# Altium schematic sheet VoltageRegulator1.SchDoc(VoltageRegulator1)
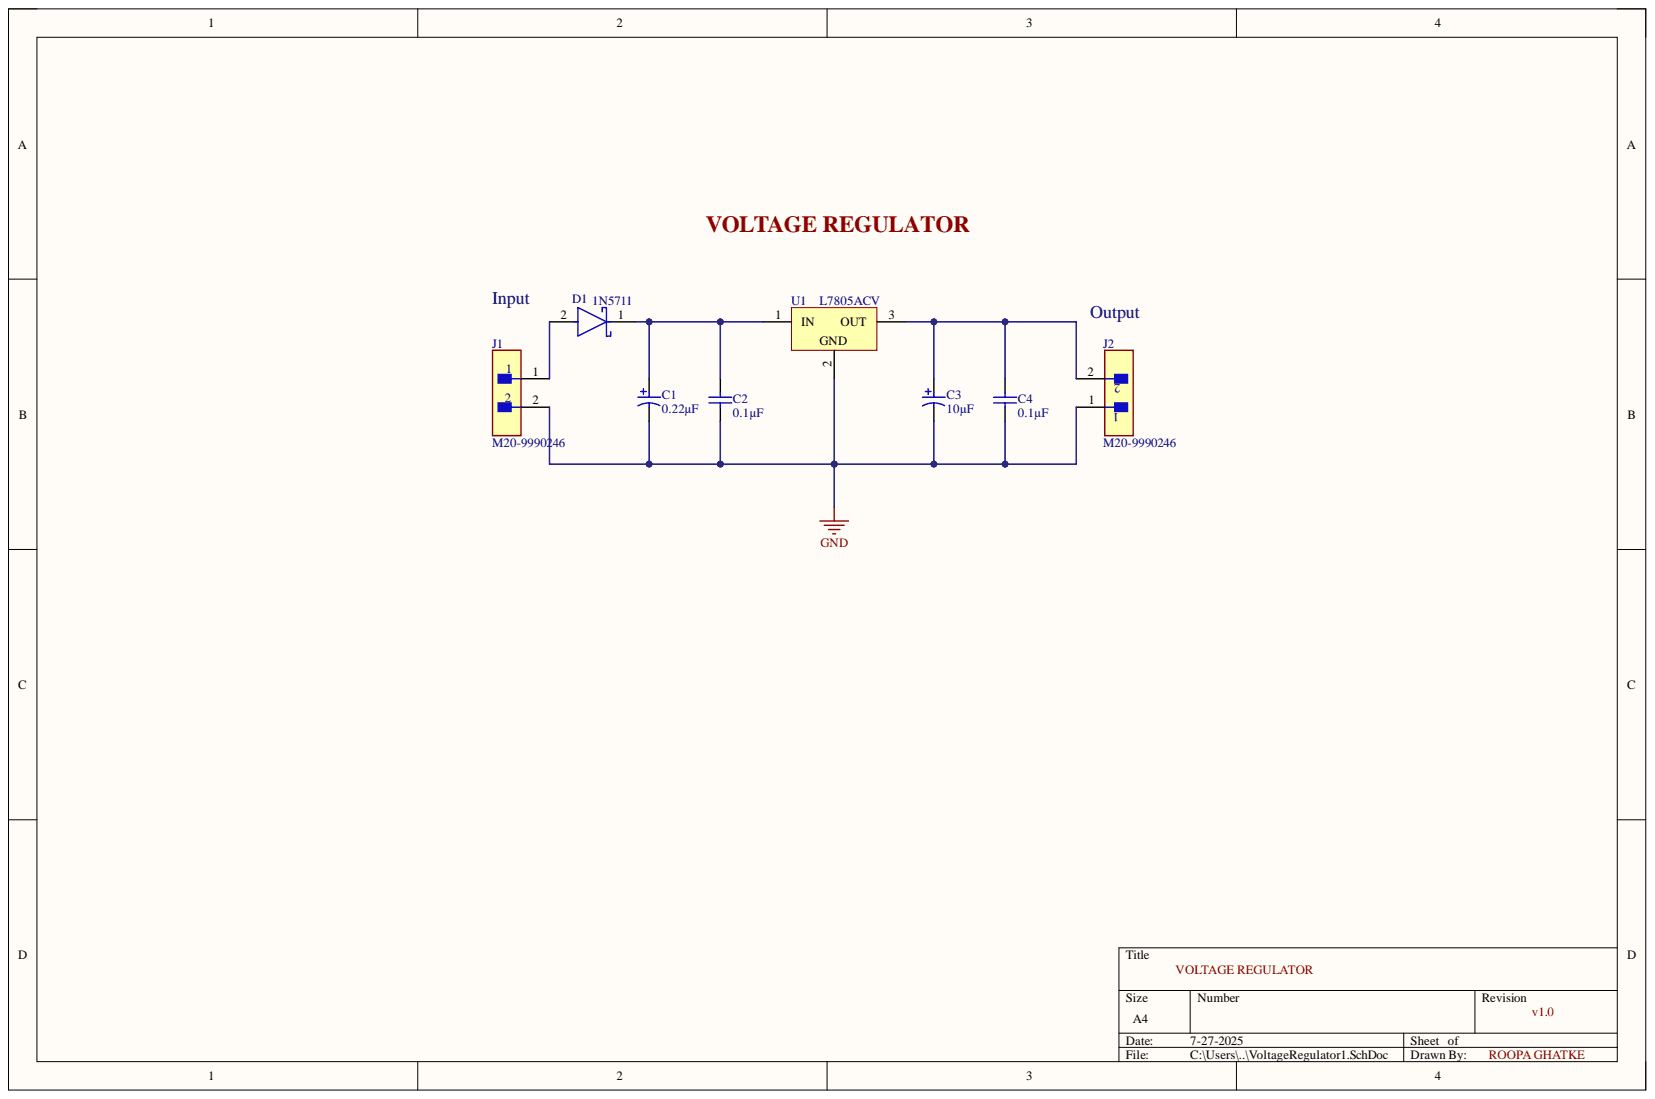
[diagram p0: VoltageRegulator1.SchDoc]

## Parts
C1  0.22µF  [CAPPR55-200-1100X500X1200]
C2  0.1µF  [CAPR51-254-508X318X736]
C3  10µF  [CAPPR55-200-1100X500X1200]
C4  0.1µF  [CAPR51-254-508X318X736]
D1  1N5711  [FP-DO-35-MFG]
J1  M20-9990246  [FP-M20-9990246-MFG]
J2  M20-9990246  [FP-M20-9990246-MFG]
U1  L7805ACV  [TO220]

## Nets
GND: C1.2 C2.2 C3.2 C4.1 J1.2 J2.1 U1.2
NetC1_1: C1.1 C2.1 D1.1 U1.1
NetC3_1: C3.1 C4.2 J2.2 U1.3
NetD1_2: D1.2 J1.1

## Library data of the parts
C1 = CMP-1665-00040-2: Aluminum Electrolytic Capacitor, 2.2 uF, +/- 20%, 50 V, -40 to 85 degC, 2-Pin THD, RoHS, Bulk; Manufacturer: Panasonic; Capacitance: 2.2uF; Dielectric Material: Aluminium; Dissipation Factor: 0.12%; Lead Diameter: 0.5mm; Lead/Base Style: Straight; Leakage Current: 3uA; Life (Hours): 2000Hour; Ripple Current: 20mA; Voltage: 50V; Case/Package: Radial; Component Kind: Standard; Contact Plating: Tin; Datasheet URL: https://industrial.panasonic.com/cdbs/www-data/pdf/RDF0000/ABA0000C1218.pdf; Datasheet Version: Rev. 05, 10/2016; Diameter: 5mm; Height: 11mm; Height - Seated (Max): 0.472inch; Lead Free: LeadFree; Lead Pitch: 2mm; Length: 11mm; Library Name: Altium Content Vault; Manufacturer URL: http://www.panasonic.com/; Max Operating Temperature: 85degC; Min Operating Temperature: -40degC; Mount: ThroughHole; Number of Pins: 2; Package Description: 2-Pin Radial Lead, Dia 5 mm, Height 12 mm; Package Quantity: 200; Package Reference: CAPPR55-200-1100X500X1200; Packaging: Bulk; Radiation Hardening: No; REACH SVHC: NoSVHC; RoHSCompliant: true; Termination: Radial; Tolerance: 20%; Voltage Rating: 50V; Voltage Rating (DC): 50V
C2 C4 = CMP-1664-00004-1: Radial Leaded Multilayer Ceramic Capacitor, 0.1 uF, +/- 10%, 50 V, -55 to 125 degC, 2-Pin THD, RoHS, Tape and Reel; Case\Package: SOT-23-5; Dropout Voltage: 260 mV; Max Input Voltage: 8 V; Max Power Dissipation: 250 mW; Output Current: 150 mA; Output Voltage: 5 V; Outputs: 1; Component Kind: Standard; ComponentLink1Description: Manufacturer URL; ComponentLink1URL: http://www.kemet.com/; ComponentLink2Description: Datasheet; ComponentLink2URL: http://www.kemet.com/docfinder?Partnumber=C320C104K5R5TA; DatasheetVersion: Dec-15; Height: 1.3 mm; Length: 3 mm; Library Name: Altium Content Vault; Max Operating Temperature: 85 degC; Min Operating Temperature: -40 degC; Mount: Surface Mount; Package Quantity: 3000; PackageDescription: 2-Pin Through Hole Device, Body 5.08 x 3.18 mm, Pitch 2.54 mm; PackageReference: CAPR51-254-508X318X736; Packaging: Tape and Reel; Pins: 5; Radiation Hardening: No; RoHSCompliant: true; Width: 1.8 mm
C3 = CMP-1665-00036-2: Aluminum Electrolytic Capacitor, 22 uF, +/- 20%, 50 V, -40 to 85 degC, 2-Pin THD, RoHS, Bulk; Manufacturer: Panasonic; Capacitance: 22uF; Dissipation Factor: 0.12%; Lead Diameter: 0.5mm; Lead/Base Style: Straight; Leakage Current: 3uA; Life (Hours): 2000Hour; Ripple Current: 100mA; Voltage: 50V; Case/Package: Radial; Component Kind: Standard; Datasheet URL: https://industrial.panasonic.com/cdbs/www-data/pdf/RDF0000/ABA0000C1218.pdf; Datasheet Version: Rev. 05, 10/2016; Diameter: 5mm; Height: 11mm; Height - Seated (Max): 0.472inch; Lead Pitch: 2mm; Length: 11mm; Library Name: Altium Content Vault; Manufacturer URL: http://www.panasonic.com/; Max Operating Temperature: 85degC; Min Operating Temperature: -40degC; Mount: ThroughHole; Package Description: 2-Pin Radial Lead, Dia 5 mm, Height 12 mm; Package Quantity: 200; Package Reference: CAPPR55-200-1100X500X1200; Packaging: Bulk; Radiation Hardening: No; REACH SVHC: NoSVHC; Ripple Current (AC): 100mA; RoHSCompliant: true; Termination: Radial; Tolerance: 20%; Voltage Rating: 50V; Voltage Rating (DC): 50V
D1 = CMP-2000-05519-2: RF DIODE SCHOTTKY 70V 430MW DO35; Manufacturer: STMicroelectronics; Capacitance: 2pF; Forward Current: 15mA; Max Junction Temp: +200°C; Value: 2pF; Automotive: No; Category: Diode; Component Kind: Standard; ComponentLink2Description: Datasheet; ComponentLink2URL: https://www.st.com/resource/en/datasheet/1n5711.pdf; Device Class L1: Discrete Semiconductors; Device Class L2: Diodes; Device Class L3: Schottky Diodes; Digikey Description: RF DIODE SCHOTTKY 70V 430MW DO35; Height: 2mm; Ipc Land Pattern Name: DIOPA762W75L450D200; Lead Free: Yes; Library Name: Altium Content Vault; Manufacturer Part Number: 1N5711; Max Repetitive Reverse Voltage: 70V; Mouser Description: Schottky Diodes & Rectifiers 15mA 70 Volt; Octopart: https://octopart.com/1n5711-stmicroelectronics-55399277; Package: DO-35; Reverse Voltage: 70V; RoHS: TRUE; Temperature: -65°C to +200°C; Temperature Range High: +200°C; Temperature Range Low: -65°C
J1 J2 = CMP-15831-000009-1: CONN HEADER VERT 2POS 2.54MM; Manufacturer: Harwin; Conn Gender: Male; Conn Orientation: Vertical; Current Rating: 3A; Number Of Contacts: 2; Automotive: No; Category: Conn; Component Kind: Standard; ComponentLink2Description: Datasheet; ComponentLink2URL: https://cdn.harwin.com/pdfs/M20-999.pdf; Contact Material: Tin,Nickel; Device Class L1: Connectors; Device Class L2: Headers and Wire Housings; Device Class L3: unset; Digikey Description: CONN HEADER VERT 2POS 2.54MM; Height: 8.99mm; Lead Free: Yes; Library Name: Altium Content Vault; Manufacturer Part Number: M20-9990246; Mouser Description: 2.54mm (0.1") Pitch SIL Vertical PC Tail Pin Header, 6.1mm mating pin height, tin, 2 contacts; Number Of Rows: 1; Octopart: https://octopart.com/m20-9990246-harwin-2590635; Package: M20-9990246; Pitch: 2.54mm; RoHS: TRUE; Temperature: -40°C to +105°C; Temperature Range High: +105°C; Temperature Range Low: -40°C
U1 = CMP-0244-00484-1: Positive Voltage Regulator, 5V, 3-Pin TO-220; Component Kind: Standard; ComponentLink1Description: Manufacturer URL; ComponentLink1URL: http://www.st.com; ComponentLink2Description: Datasheet; ComponentLink2URL: http://www.st.com/internet/com/TECHNICAL_RESOURCES/TECHNICAL_LITERATURE/DATASHEET/CD00000444.pdf; ComponentLink3Description: Package Specification; ComponentLink3URL: http://www.st.com/internet/com/TECHNICAL_RESOURCES/TECHNICAL_LITERATURE/PACKAGE_INFORMATION/CD00004649.pdf; DatasheetVersion: 16/11/2011; Library Name: Altium Content Vault; PackageDescription: TO, Thru-Hole, Vertical, Heatsink Mounted; 3 In-Line Leads; Pitch 2.54 mm; PackageReference: TO220; PackageVersion: ver3.0
